# Altium schematic sheet DCDC.SchDoc(DCDC)
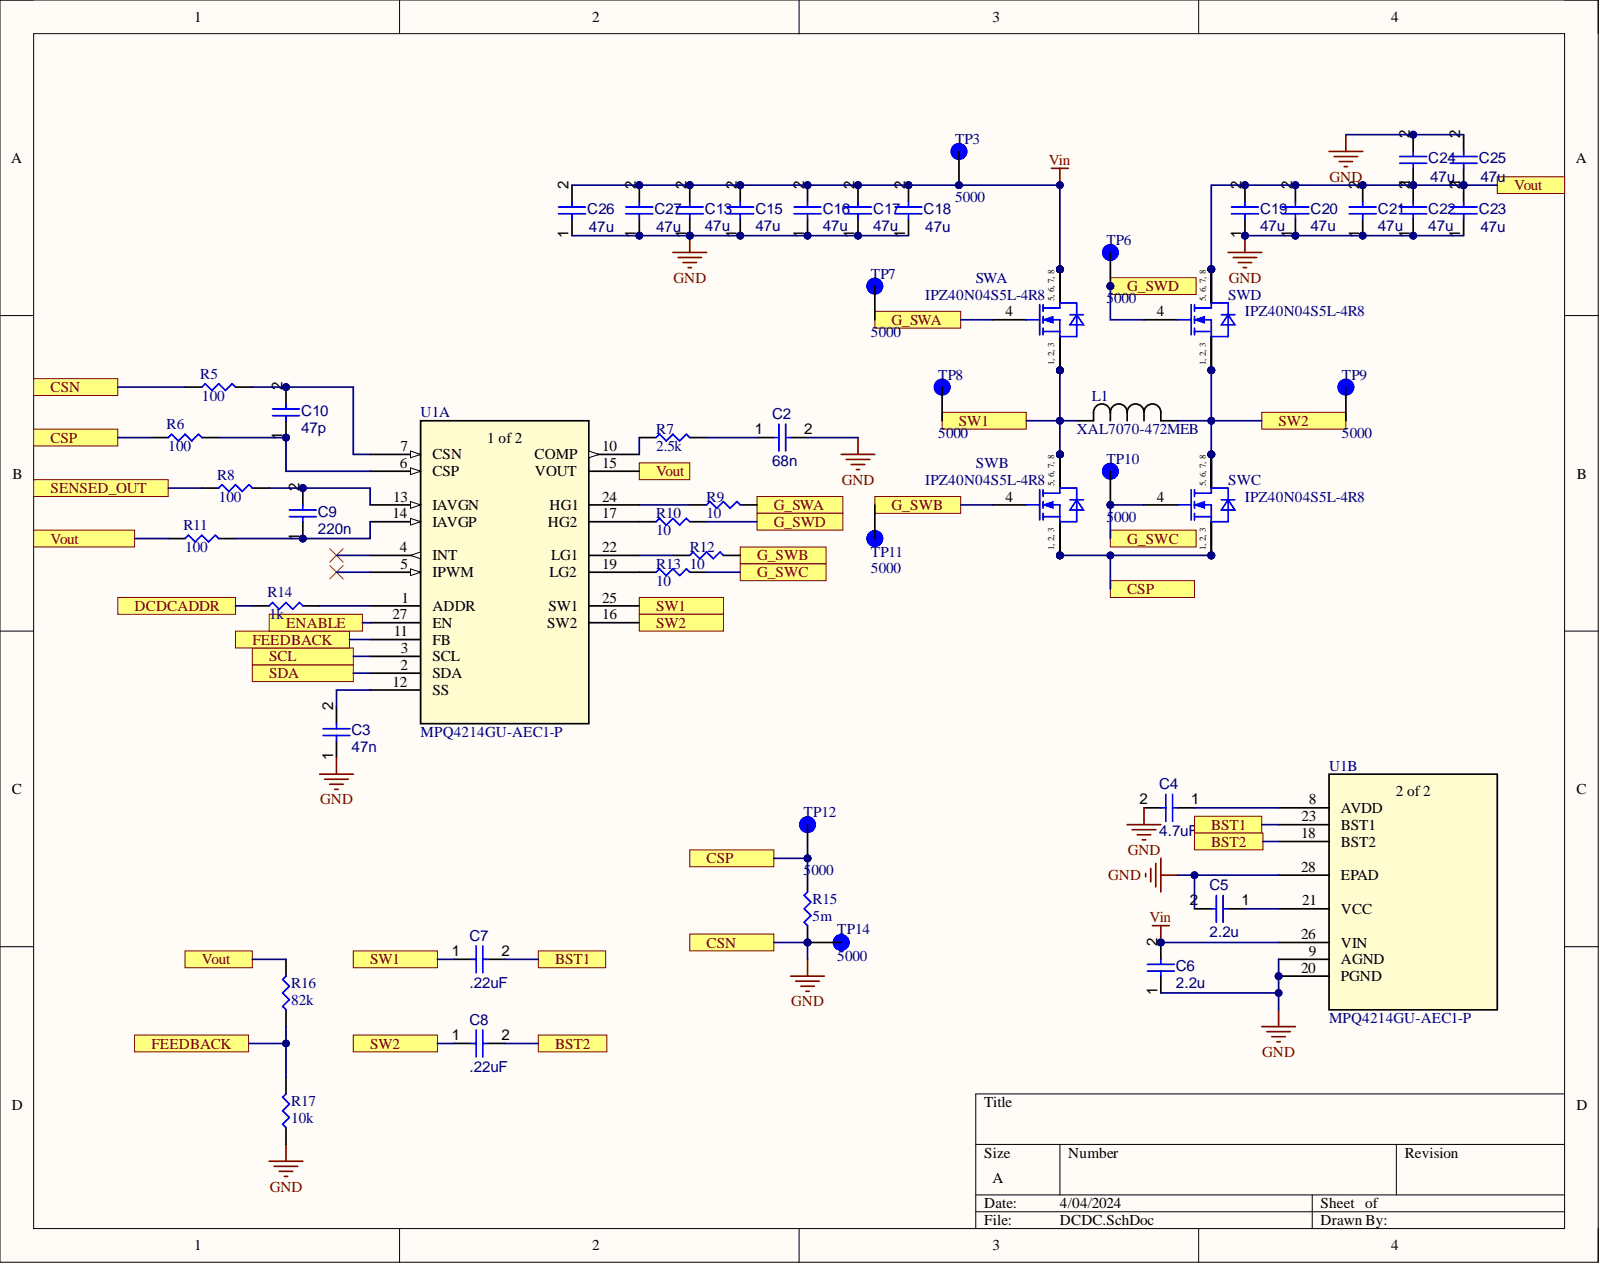
[diagram p0: DCDC.SchDoc]

## Parts
C2  68n
C3  47n
C4  4.7uF
C5  2.2u
C6  2.2u
C7  .22uF
C8  .22uF
C9  220n
C10  47p
C13  47u
C15  47u
C16  47u
C17  47u
C18  47u
C19  47u
C20  47u
C21  47u
C22  47u
C23  47u
C24  47u
C25  47u
C26  47u
C27  47u
L1  XAL7070-472MEB
R5  100
R6  100
R7  2.5k
R8  100
R9  10
R10  10
R11  100
R12  10
R13  10
R14  1k
R15  5m
R16  82k
R17  10k
SWA  IPZ40N04S5L-4R8
SWB  IPZ40N04S5L-4R8
SWC  IPZ40N04S5L-4R8
SWD  IPZ40N04S5L-4R8
TP3  5000
TP6  5000
TP7  5000
TP8  5000
TP9  5000
TP10  5000
TP11  5000
TP12  5000
TP14  5000
U1  MPQ4214GU-AEC1-P  (parts A,B)

## Ports
BST1, BST2, CSN, CSP, DCDCADDR, ENABLE, FEEDBACK, G_SWA, G_SWB, G_SWC, G_SWD, SCL, SDA, SENSED_OUT, SW1, SW2, Vout

## Nets
Vin: C6.2 C13.2 C15.2 C16.2 C17.2 C18.2 C26.2 C27.2 SWA.5 SWA.6 SWA.7 SWA.8 TP3.TP U1.26(VIN)
BST1: C7.2 U1.23(BST1) ; port BST1
BST2: C8.2 U1.18(BST2) ; port BST2
CSP: R6.1 R15.2 SWB.1 SWB.2 SWB.3 SWC.1 SWC.2 SWC.3 TP12.TP ; port CSP
DCDCADDR: R14.1 ; port DCDCADDR
ENABLE: U1.27(EN) ; port ENABLE
FEEDBACK: R16.1 R17.2 U1.11(FB) ; port FEEDBACK
G_SWA: R9.2 SWA.4 TP7.TP ; port G_SWA
G_SWB: R12.2 SWB.4 TP11.TP ; port G_SWB
G_SWC: R13.2 SWC.4 TP10.TP ; port G_SWC
G_SWD: R10.2 SWD.4 TP6.TP ; port G_SWD
GND: C2.2 C3.1 C4.2 C5.2 C6.1 C13.1 C15.1 C16.1 C17.1 C18.1 C19.1 C20.1 C21.1 C22.1 C23.1 C24.2 C25.2 C26.1 C27.1 R5.1 R15.1 R17.1 TP14.TP U1.9(AGND) U1.20(PGND) U1.28(EPAD) ; port CSN
SCL: U1.3(SCL) ; port SCL
SDA: U1.2(SDA) ; port SDA
SENSED_OUT: R8.1 ; port SENSED_OUT
SW1: C7.1 L1.2 SWA.1 SWA.2 SWA.3 SWB.5 SWB.6 SWB.7 SWB.8 TP8.TP U1.25(SW1) ; port SW1
SW2: C8.1 L1.1 SWC.5 SWC.6 SWC.7 SWC.8 SWD.1 SWD.2 SWD.3 TP9.TP U1.16(SW2) ; port SW2
Vout: C19.2 C20.2 C21.2 C22.2 C23.2 C24.1 C25.1 R11.1 R16.2 SWD.5 SWD.6 SWD.7 SWD.8 U1.15(VOUT) ; port Vout
NetC2_1: C2.1 R7.2
NetC3_2: C3.2 U1.12(SS)
NetC4_1: C4.1 U1.8(AVDD)
NetC5_1: C5.1 U1.21(VCC)
NetC9_1: C9.1 R11.2 U1.14(IAVGP)
NetC9_2: C9.2 R8.2 U1.13(IAVGN)
NetC10_1: C10.1 R6.2 U1.6(CSP)
NetC10_2: C10.2 R5.2 U1.7(CSN)
NetR7_1: R7.1 U1.10(COMP)
NetR9_1: R9.1 U1.24(HG1)
NetR10_1: R10.1 U1.17(HG2)
NetR12_1: R12.1 U1.22(LG1)
NetR13_1: R13.1 U1.19(LG2)
NetR14_2: R14.2 U1.1(ADDR)

Not connected: U1 4(INT) 5(IPWM)

## Library data of the parts
C2-C10 C13 C15-C27 = CMP-005-000638-1: Chip Capacitor, 1µF +/-40%, 50V, 0603, Thickness 1 mm; Available Preview Images: 2D; Component Kind: Standard; Design Item ID: CMP-001-00078-2; Source: Integrated_Library - 12.03.21 - 15.39.SchLib; Library Name: Illini Formula Electric
L1 = CMP-052-000092-1: No Description Available; Mfr_Name: Coilcraft; Type: DEV; Component Kind: Standard; Component Type: Standard; Design Item ID: XAL7070-472MEB; Manufacturer_Part_Number: XAL7070-472MEB; Pin Count: 2; RefDes: RefDes; Source: XAL7070-472MEB.SchLib; Copyright: Copyright (C) 2024 Ultra Librarian. All rights reserved.; Library Name: Illini Formula Electric
R5-R17 = CMP-055-000088-1: Component Kind: Standard; Design Item ID: RES-0603; Library Name: Illini Formula Electric; Source: ife19_Elec_Library.SchLib
SWA SWB SWC SWD = CMP-051-000234-1: Insulated-Gate Field-Effect Transistor (IGFET), N-Channel, Enhancement, Body Diode, Pin 1 Source, 2 Source, 3 Source, 4 Gate, 5 Drain, 6 Drain, 7 Drain, 8 Drain, 8 Pins; Manufacturer: Infineon Technologies; Component Kind: Standard; Design Item ID: IPZ40N04S5L-4R8; ManufacturerPartNumber: IPZ40N04S5L-4R8; PackageReference: PG-TSDSON-8-32; Source: IPZ40N04S5L-4R8.SchLib; Library Name: Illini Formula Electric
TP3 TP6-TP12 TP14 = CMP-00067-1: Test Point Miniature THM H .300 Nylon 46 Insulated Red PhosBronze/Silver; MF: Keystone Electronics; Check_prices: https://www.snapeda.com/parts/5000/Keystone+Electronics/view-part/?ref=eda; Component Kind: Standard; Component Type: Standard; Design Item ID: 5000; MP: 5000; Package: Test Point Keystone Electronics; Pin Count: 1; SnapEDA_Link: https://www.snapeda.com/parts/5000/Keystone+Electronics/view-part/?ref=snap; Source: 5000.SchLib; Library Name: Illini Formula Electric
U1 = CMP-139-000000-1: No Description Available; Mfr_Name: Monolithic Power Systems; Component Kind: Standard; Component Type: Standard; Design Item ID: MPQ4214GU-AEC1-P; Pin Count: 28; RefDes: RefDes; Source: MPQ4214GU-AEC1-P.SchLib; Type: Type; Library Name: Illini Formula Electric
